# Altium schematic sheet [12] - CONNECTORS.SchDoc
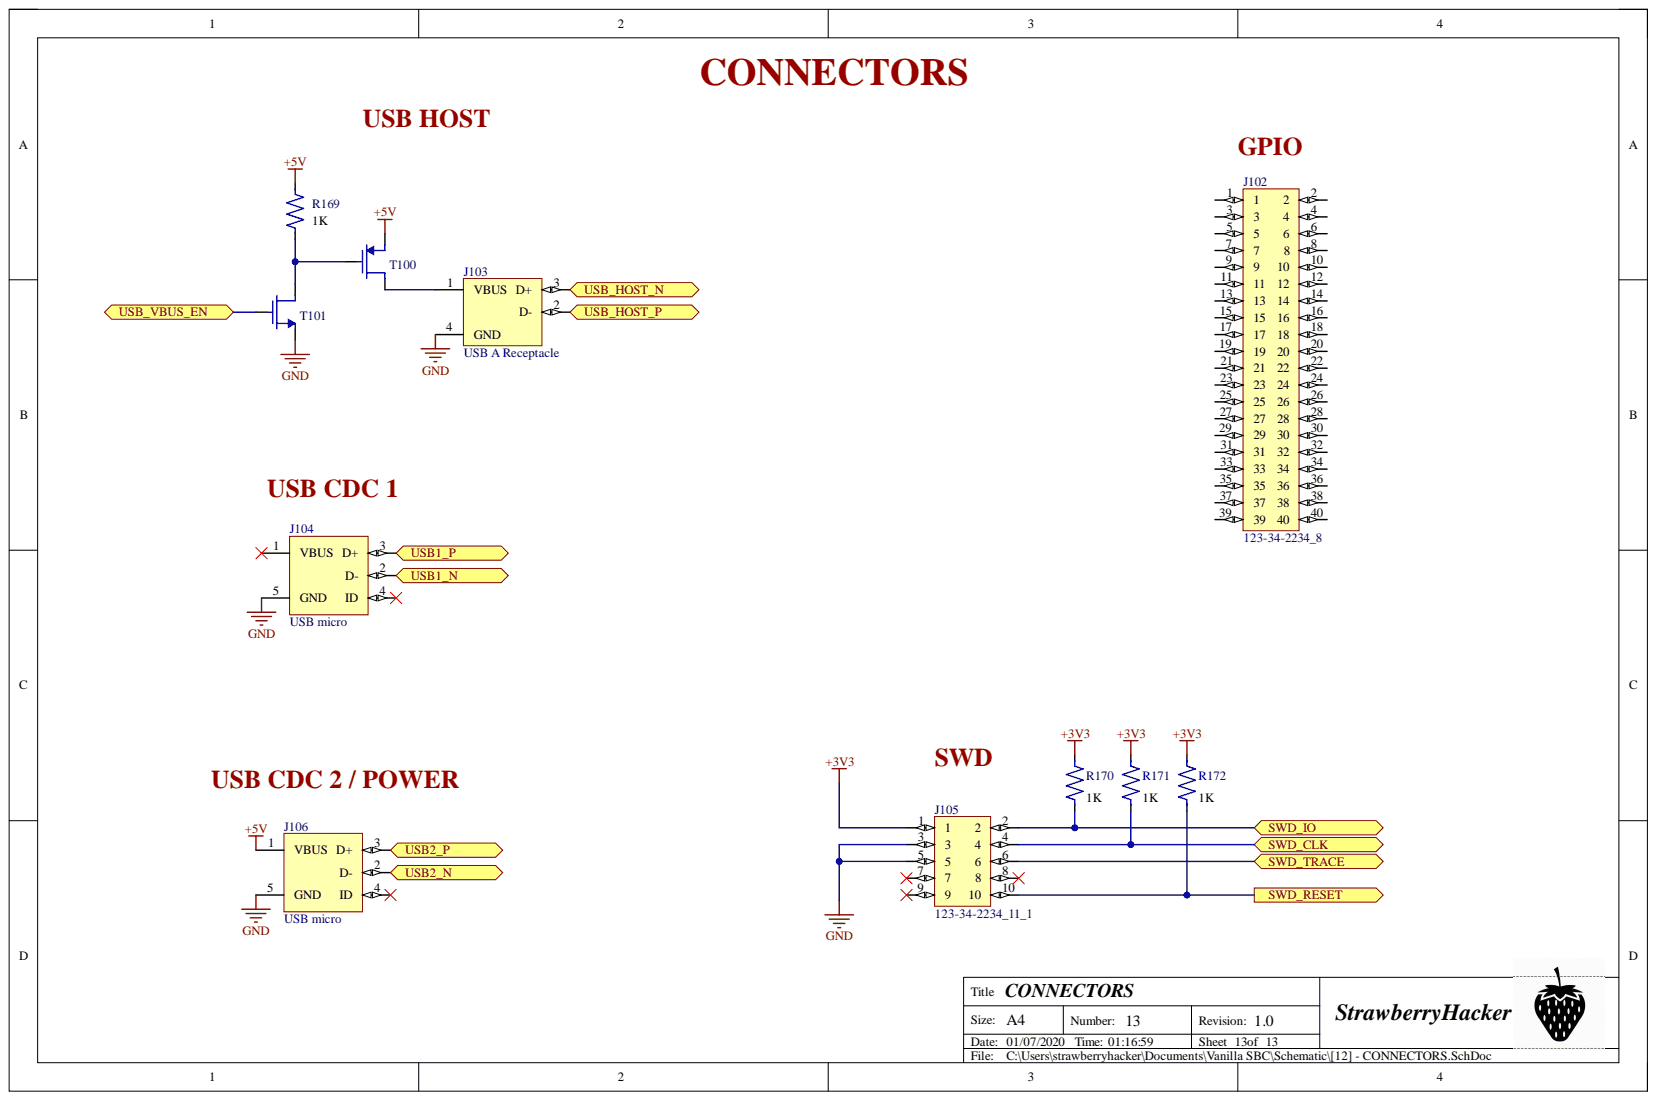
[diagram p0: [12] - CONNECTORS.SchDoc]

## Parts
J102  123-34-2234_8  [Header 2x20 male]
J103  USB A Receptacle  [USB A Right angle]
J104  USB micro  [USB micro]
J105  123-34-2234_11_1  [Header 2x5 male 1.27mm]
J106  USB micro  [USB micro]
R169  value 1K  [R0603]  (hidden comment: CPF-A-0603B100KE1)
R170  value 1K  [R0402]  (hidden comment: CRCW04020000Z0ED)
R171  value 1K  [R0402]  (hidden comment: CRCW04020000Z0ED)
R172  value 1K  [R0402]  (hidden comment: CRCW04020000Z0ED)
T100  DMP1045U  [DMP1045U]  (value not drawn)
T101  DMG6968U  [DMG6968U]  (value not drawn)

## Ports
SWD_CLK, SWD_IO, SWD_RESET, SWD_TRACE, USB1_N, USB1_P, USB2_N, USB2_P, USB_HOST_N, USB_HOST_P, USB_VBUS_EN

## Nets
+3V3: J105.1 R170.2 R171.2 R172.2
+5V: J106.1(VBUS) R169.2 T100.2
GND: J103.4(GND) J104.5(GND) J105.3 J105.5 J106.5(GND) T101.2
SWD_CLK: J105.4 R171.1 ; port SWD_CLK
SWD_IO: J105.2 R170.1 ; port SWD_IO
SWD_RESET: J105.10 R172.1 ; port SWD_RESET
SWD_TRACE: J105.6 ; port SWD_TRACE
USB1_N: J104.2(D-) ; port USB1_N
USB1_P: J104.3(D+) ; port USB1_P
USB2_N: J106.2(D-) ; port USB2_N
USB2_P: J106.3(D+) ; port USB2_P
USB_HOST_N: J103.3(D+) ; port USB_HOST_N
USB_HOST_P: J103.2(D-) ; port USB_HOST_P
USB_VBUS_EN: T101.1 ; port USB_VBUS_EN
NetJ103_1: J103.1(VBUS) T100.3
NetR169_1: R169.1 T100.1 T101.3

Not connected: J102 1 2 3 4 5 6 7 8 9 10 11 12 13 14 15 16 17 18 19 20 21 22 23 24 25 26 27 28 29 30 31 32 33 34 35 36 37 38 39 40; J104 1(VBUS) 4(ID); J105 7 8 9; J106 4(ID)

## Library data of the parts
J102 = 123-34-2234_8: Header 2x20 male 2.54mm; Component Kind: Standard; Library Name: Connectors.SchLib
J103 = MC32593_1: USB A Right angle; Component Kind: Standard; Library Name: Connectors.SchLib; Supplier 1: Farnell; Supplier Part Number 1: 1696534
J104 J106 = 47590-0001: USB B micro; Component Kind: Standard; Library Name: Connectors.SchLib; Supplier 1: Farnell; Supplier Part Number 1: 1568022
J105 = 123-34-2234_11_1: Header 2x5 male 1.27mm; Component Kind: Standard; Library Name: Connectors.SchLib
R169 = CPF-A-0603B100KE1: R0603; Component Kind: Standard; Library Name: Passive components.SchLib
R170-R172 = CRCW04020000Z0ED: R0402; Component Kind: Standard; Library Name: Passive components.SchLib
T100 = DMP1045U: PMOS 5.2A -550mV; Component Kind: Standard; Cont. drain current: 5.2A; Library Name: Transistors.SchLib; Supplier 1: Farnell; Supplier Part Number 1: 2061526; Threshold voltage: -550mV
T101 = DMG6968U: NMOS 6.5A 500mV; Component Kind: Standard; Cont. drain current: 6.5A; Library Name: Transistors.SchLib; Supplier 1: Farnell; Supplier Part Number 1: 1843757; Threshold voltage: 500mV
